# Altium schematic sheet Sheet3.SchDoc
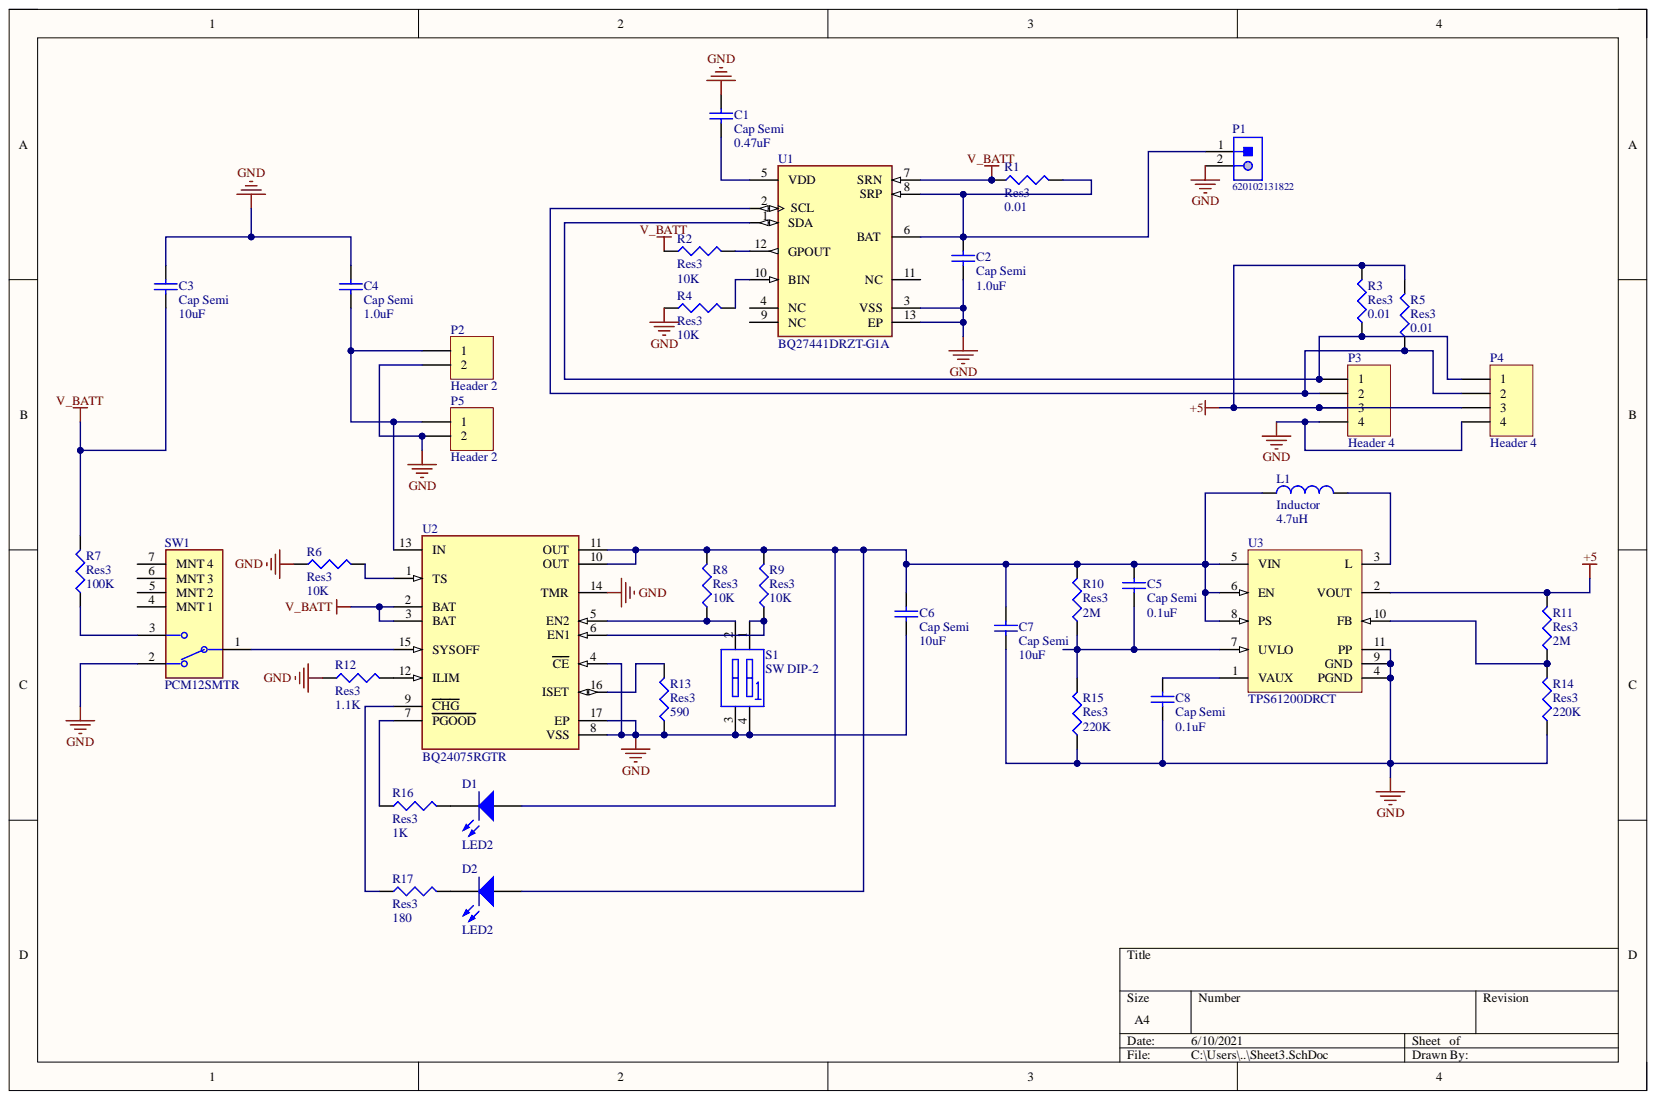
[diagram p0: Sheet3.SchDoc]

## Parts
C1  Cap Semi  value 0.47uF  [C1206]
C2  Cap Semi  value 1.0uF  [C1206]
C3  Cap Semi  value 10uF  [C1206]
C4  Cap Semi  value 1.0uF  [C1206]
C5  Cap Semi  value 0.1uF  [C1206]
C6  Cap Semi  value 10uF  [C1206]
C7  Cap Semi  value 10uF  [C1206]
C8  Cap Semi  value 0.1uF  [C1206]
D1  LED2  [3.2X1.6X1.1]
D2  LED2  [3.2X1.6X1.1]
L1  Inductor  value 4.7uH  [0402-A]
P1  620102131822  [620102131822]
P2  Header 2  [HDR1X2]
P3  Header 4  [HDR1X4]
P4  Header 4  [HDR1X4]
P5  Header 2  [HDR1X2]
R1  Res3  value 0.01  [J1-0603]
R2  Res3  value 10K  [J1-0603]
R3  Res3  value 0.01  [J1-0603]
R4  Res3  value 10K  [J1-0603]
R5  Res3  value 0.01  [J1-0603]
R6  Res3  value 10K  [J1-0603]
R7  Res3  value 100K  [J1-0603]
R8  Res3  value 10K  [J1-0603]
R9  Res3  value 10K  [J1-0603]
R10  Res3  value 2M  [J1-0603]
R11  Res3  value 2M  [J1-0603]
R12  Res3  value 1.1K  [J1-0603]
R13  Res3  value 590  [J1-0603]
R14  Res3  value 220K  [J1-0603]
R15  Res3  value 220K  [J1-0603]
R16  Res3  value 1K  [J1-0603]
R17  Res3  value 180  [J1-0603]
S1  SW DIP-2  [DIP-4]
SW1  PCM12SMTR  [FP-PCM12SMTR-MFG]
U1  BQ27441DRZT-G1A  [FP-DRZ0012A-MFG]
U2  BQ24075RGTR  [FP-RGT0016C-IPC_A]
U3  TPS61200DRCT  [DRC0010J_V]

## Nets
+5: P3.3 P4.3 R3.2 R5.2 R11.2 U3.2(VOUT)
GND: C1.2 C2.1 C3.2 C4.2 C6.1 C7.1 C8.1 P1.2 P2.2 P3.4 P4.4 P5.2 R4.1 R6.1 R12.1 R13.1 R14.1 R15.1 S1.3 S1.4 SW1.2 U1.3(VSS) U1.13(EP) U2.4(CE) U2.8(VSS) U2.14(TMR) U2.17(EP) U3.4(PGND) U3.9(GND) U3.11(PP)
V_BATT: C3.1 R1.1 R2.1 R7.2 U1.7(SRN) U2.2(BAT) U2.3(BAT)
NetC1_1: C1.1 U1.5(VDD)
NetC2_2: C2.2 P1.1 R1.2 U1.6(BAT) U1.8(SRP)
NetC4_1: C4.1 P2.1 P5.1 U2.13(IN)
NetC5_1: C5.1 R10.1 R15.2 U3.7(UVLO)
NetC5_2: C5.2 C6.2 C7.2 D1.1 D2.1 L1.1 R8.2 R9.2 R10.2 U2.10(OUT) U2.11(OUT) U3.5(VIN) U3.6(EN) U3.8(PS)
NetC8_2: C8.2 U3.1(VAUX)
NetD1_2: D1.2 R16.2
NetD2_2: D2.2 R17.2
NetL1_2: L1.2 U3.3(L)
NetP3_1: P3.1 P4.1 R3.1 U1.1(SDA)
NetP3_2: P3.2 P4.2 R5.1 U1.2(SCL)
NetR2_2: R2.2 U1.12(GPOUT)
NetR4_2: R4.2 U1.10(BIN)
NetR6_2: R6.2 U2.1(TS)
NetR7_1: R7.1 SW1.3
NetR8_1: R8.1 S1.2 U2.5(EN2)
NetR9_1: R9.1 S1.1 U2.6(EN1)
NetR11_1: R11.1 R14.2 U3.10(FB)
NetR12_2: R12.2 U2.12(ILIM)
NetR13_2: R13.2 U2.16(ISET)
NetR16_1: R16.1 U2.7(PGOOD)
NetR17_1: R17.1 U2.9(CHG)
NetSW1_1: SW1.1 U2.15(SYSOFF)

Not connected: SW1 4(MNT_1) 5(MNT_2) 6(MNT_3) 7(MNT_4); U1 4(NC) 9(NC) 11(NC)

## Library data of the parts
C1-C8 = Cap Semi: Capacitor (Semiconductor SIM Model); Component Kind: Standard; LatestRevisionDate: 29-May-2009; LatestRevisionNote: IPC-7351 Footprint Added.; Library Name: Miscellaneous Devices.IntLib; PackageDescription: Chip Capacitor; PackageReference: C1206; Published: 8-Jun-2000; Signal Integrity: Cap Semi; Simulation: CAP; Publisher: Altium Limited
D1 D2 = LED2: Typical RED, GREEN, YELLOW, AMBER GaAs LED; Component Kind: Standard; LatestRevisionDate: 17-Jul-2002; LatestRevisionNote: Re-released for DXP Platform.; Library Name: Miscellaneous Devices.IntLib; PackageDescription: SM LED; 2 Flat Leads; PackageReference: 3.2X1.6X1.1; PackageVersion: Feb-2002; Published: 8-Jun-2000; Simulation: LED2; Publisher: Altium Limited
L1 = Inductor: Code_IEC: 1005; Code_JEITA: 0402; Component Kind: Standard; Description: Inductor; LatestRevisionDate: 29-May-2009; LatestRevisionNote: IPC-7351 Footprint Added.; Library Name: Miscellaneous Devices.IntLib; PackageDescription: Chip Inductor; PackageReference: 0402-A; Published: 8-Jun-2000; Signal Integrity: Inductor; Simulation: INDUCTOR; Publisher: Altium Limited
P1 = CMP-1502-00669-1: Male Shrouded Header WR-WTB, SMT, Right Angled, pitch 2 mm, 1 x 2 position; Manufacturer: Wurth Elektronik; Contact Resistance: 20mOhm; Pitch: 2mm; Position: 1x2; Working Voltage: 100VAC; Component Kind: Standard; Datasheet URL: https://katalog.we-online.de/em/datasheet/6201xx131822.pdf; Gender: Male; Library Name: Altium Content Vault; Manufacturer URL: http://www.we-online.de; Mounting Technology: SMT; Operating Temperature: -25to85degC; Orientation: RightAngled; Package Reference: 620102131822; Packaging: TapeandReel; Part Number: 620102131822; Publisher: WurthElektronik
P2 P5 = Header 2: Header, 2-Pin; Component Kind: Standard; LatestRevisionDate: 17-Jul-2002; LatestRevisionNote: Re-released for DXP Platform.; Library Name: Miscellaneous Connectors.IntLib; Signal Integrity: Connector; Publisher: Altium Limited
P3 P4 = Header 4: Header, 4-Pin; Component Kind: Standard; LatestRevisionDate: 17-Jul-2002; LatestRevisionNote: Re-released for DXP Platform.; Library Name: Miscellaneous Connectors.IntLib; Signal Integrity: Connector; Publisher: Altium Limited
R1 R3-R17 = Res3: Resistor; Component Kind: Standard; LatestRevisionDate: 29-May-2009; LatestRevisionNote: IPC-7351 Footprint Added.; Library Name: Miscellaneous Devices.IntLib; PackageDescription: Chip Resistor; PackageReference: J1-0603; Published: 8-Jun-2000; Signal Integrity: Res; Simulation: RESISTOR; Publisher: Altium Limited
R2 = Res3: Resistor; Value: 10K; Component Kind: Standard; LatestRevisionDate: 29-May-2009; LatestRevisionNote: IPC-7351 Footprint Added.; Library Name: Miscellaneous Devices.IntLib; PackageDescription: Chip Resistor; PackageReference: J1-0603; Published: 8-Jun-2000; Signal Integrity: Res; Simulation: RESISTOR; Publisher: Altium Limited
S1 = SW DIP-2: DIP Switch, 2 Position, SPST; Component Kind: Standard; LatestRevisionDate: 17-Jul-2002; LatestRevisionNote: Re-released for DXP Platform.; Library Name: Miscellaneous Devices.IntLib; PackageReference: DIP-4; Published: 24-Mar-1999; Simulation: dpsw2; Publisher: Altium Limited
SW1 = CMP-2000-06884-2: SWITCH SLIDE SPDT 300MA 6V; Actuator Length: 1.5mm; Actuator Material: Nylon; Actuator Type: Standard; Contact Resistance: 70mR; Insulation Resistance: 100mR; Mechanical Life: 10000Cycles; Switch Function: On-On; Component Kind: Standard; Contact Current Rating: 300mA; Contact Material: Copper Alloy; Contact Plating: Silver; Current Rating: 300mA; Depth: 5mm; Lead Free: Lead Free; Length: 7.7mm; Library Name: Altium Content Vault; Max Current Rating: 300mA; Max Operating Temperature: 85°C; Max Voltage Rating (DC): 6V; Min Operating Temperature: -20°C; Mount: Surface Mount; Orientation: Right Angle; Package Quantity: 1; Packaging: Tape and Reel; REACH SVHC: No SVHC; Switch Travel: 1.5mm; Termination: Gull Wing; Throw Configuration: SPDT; Voltage Rating (AC): 6V; Voltage Rating (DC): 6V; Width: 6.7mm
U1 = CMP-04911-000005-1: IC BATT FUEL GAUGE LICOO2 12SON; Manufacturer: Texas Instruments; Max Supply Voltage: 4.5V; Min Supply Voltage: 2.45V; Nominal Supply Current: 93uA; Number Of Cells: 1; Output Voltage: 1.8V; Standoff Height: 0mm; Ambient Temperature Range High: +85°C; Ambient Temperature Range Low: -40°C; Automotive: No; Category: IC; Component Kind: Standard; ComponentLink2Description: Datasheet; ComponentLink2URL: http://www.ti.com/lit/ds/symlink/bq27441-g1.pdf; Device Class L1: Integrated Circuits (ICs); Device Class L2: Power Management ICs; Device Class L3: Battery Management; Digikey Description: IC BATT FUEL GAUGE LICOO2 12SON; Height: 1mm; Lead Free: Yes; Library Name: Altium Content Vault; Manufacturer Part Number: BQ27441DRZT-G1A; Mouser Description: Battery Management System-Side Impdance Trck Bttry Fuel Gge; Number Of Outputs: 1; Octopart: https://octopart.com/bq27441drzt-g1a-texas+instruments-30555831; Package: DRZ0012A; RoHS: TRUE; Temperature: -40°C to +85°C; Temperature Range High: +85°C; Temperature Range Low: -40°C
U2 = CMP-0321-00238-3: IC LI-ION CHARGE MGMT 16-QFN; Battery Chemistry: LITHIUM-ION; Battery Pack Voltage: 4.2V; Fault Protection: Over Voltage; Interface: USB; Max Charge Current: 1.5A; Max Supply Voltage: 6.4V; Min Input Voltage: 4.3V; Min Supply Voltage: 4.35V; Number of Cells: 1; Case/Package: VQFN; Component Kind: Standard; Contact Plating: Gold; Lead Free: Lead Free; Library Name: Altium Content Vault; Max Operating Temperature: 125°C; Min Operating Temperature: -40°C; Number of Pins: 16; Output Current: 1.5A; Output Voltage: 4.2V; Package Quantity: 3000; Packaging: Tape and Reel; Radiation Hardening: No; RoHS Compliant: Yes; Series: -; Weight: 0.000808oz
U3 = CMP-0347-00023-2: Boost Voltage Converter with 0.3 to 5.5 V Input and 1.8 to 5.5 V Output, -40 to 85 degC, 10-Pin SON (DRC), Green (RoHS & no Sb/Br); Manufacturer: Texas Instruments; Regulated Outputs(#): 1; SubFamily: Step-Up Regulator; Switching Frequency(Max)(kHz): 1250; Synchronous Rectification: TRUE; Vin(Max)(V): 5.5; Vin(Min)(V): 0.3; Vout(Min)(V): 1.8; Component Kind: Standard; ComponentLink1Description: Datasheet; ComponentLink1URL: http://www.ti.com/general/docs/lit/getliterature.tsp?genericPartNumber=TPS61200&fileType=pdf; ComponentLink2Description: Package Specification; ComponentLink2URL: http://www.ti.com/litv/pdf/mpds117k; DatasheetVersion: SLVS577B; Eco-Plan: Green (RoHS & no Sb/Br); Library Name: Altium Content Vault; Mounting Technology: Surface Mount; PackageDescription: 10-Pin Plastic Small Outline No-Lead, 3 x 3 x 1 mm Body, 0.5 mm Pitch, Exposed Pad; PackageReference: DRC0010J; PackageVersion: revL, Sep-2011; PartNumber: TPS61200DRCT; Topology: Boost; Vout(Max)(V): 5.5
